# Altium schematic sheet HANC_Digital_TS.SchDoc(HANC_Digital_TS)
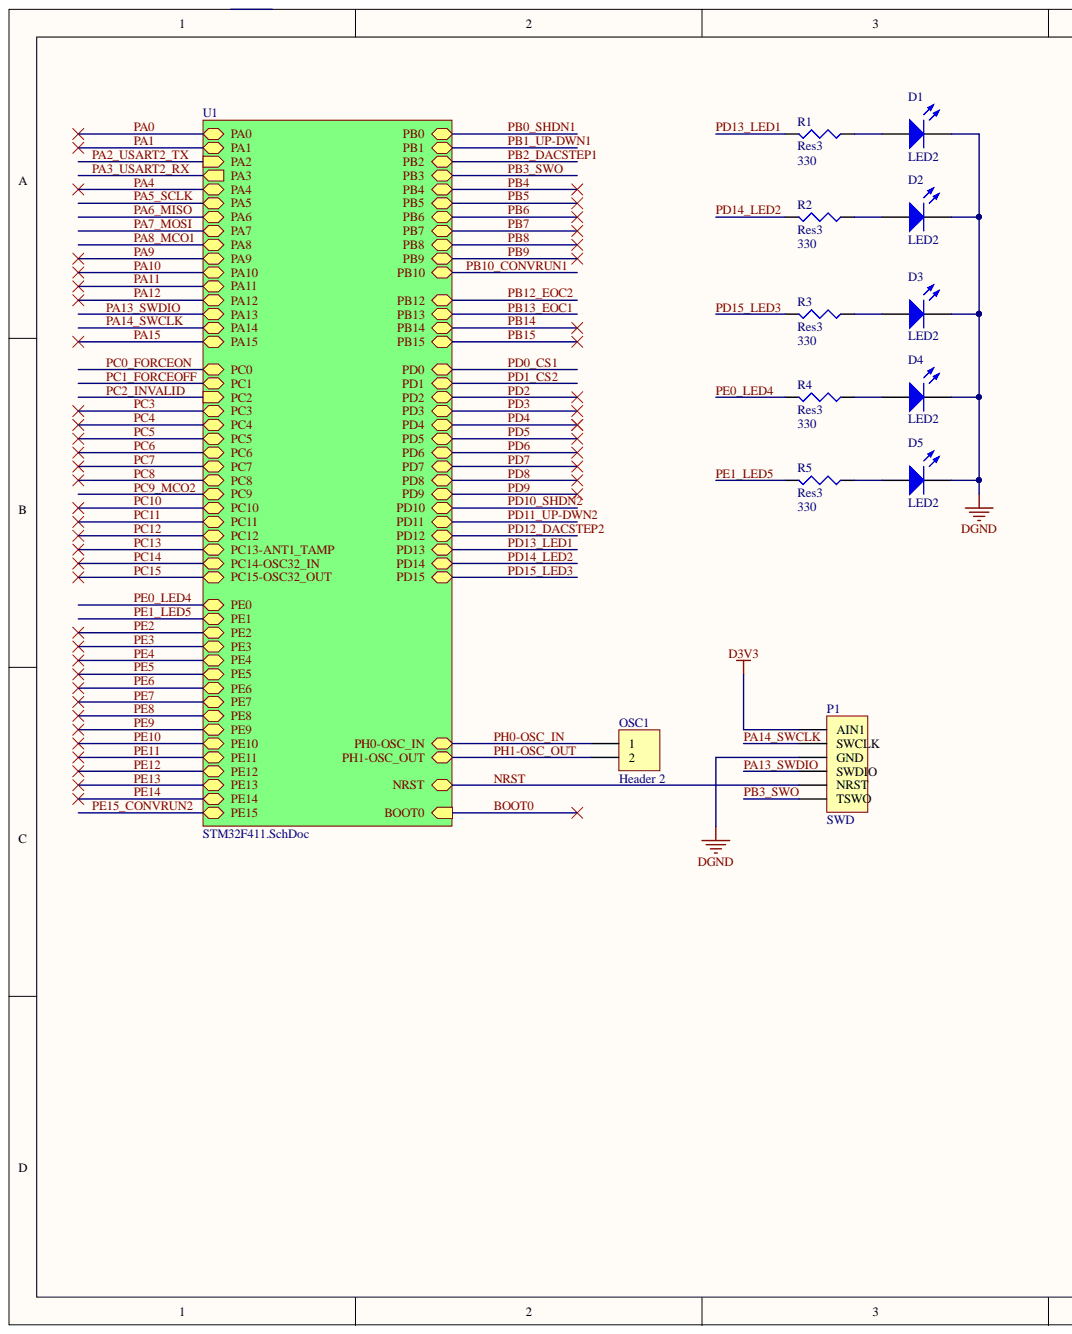
[diagram p0: HANC_Digital_TS.SchDoc, left]
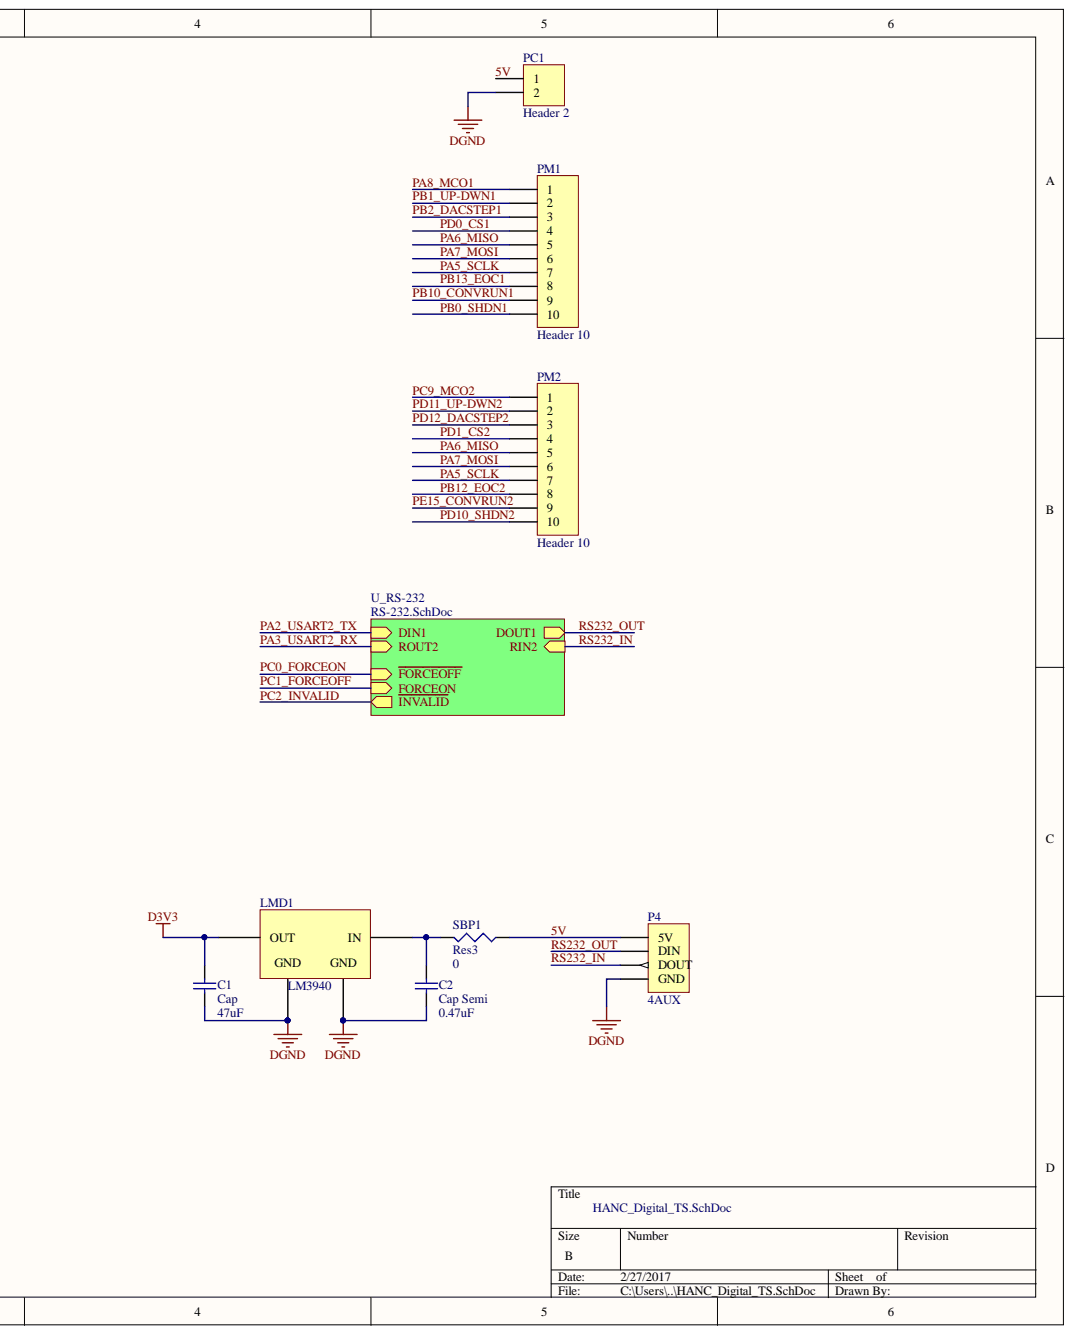
[diagram p1: HANC_Digital_TS.SchDoc, right]

## Parts
C1  Cap  value 47uF  [1608[0603]]
C2  Cap Semi  value 0.47uF  [1608[0603]]
D1  LED2  [3.2X1.6X1.1]
D2  LED2  [3.2X1.6X1.1]
D3  LED2  [3.2X1.6X1.1]
D4  LED2  [3.2X1.6X1.1]
D5  LED2  [3.2X1.6X1.1]
LMD1  LM3940  [SOT223_N]
OSC1  Header 2  [HDR1X2]
P1  SWD  [HDR1X6]
P4  4AUX  [HDR1X4]
PC1  Header 2  [HDR1X2]
PM1  Header 10  [HDR1X10]
PM2  Header 10  [HDR1X10]
R1  Res3  value 330  [0402]
R2  Res3  value 330  [0402]
R3  Res3  value 330  [0402]
R4  Res3  value 330  [0402]
R5  Res3  value 330  [0402]
SBP1  Res3  [J1-0603]

## Nets
D3V3: C1.2 LMD1.3(OUT) P1.1(AIN1)
DGND: C1.1 C2.1 D1.2 D2.2 D3.2 D4.2 D5.2 LMD1.2 LMD1.4 P1.3(GND) P4.4(GND) PC1.2
5V: P4.1(5V) PC1.1 SBP1.1
NRST: P1.5(NRST)
PA5_SCLK: PM1.7 PM2.7
PA6_MISO: PM1.5 PM2.5
PA7_MOSI: PM1.6 PM2.6
PA8_MCO1: PM1.1
PA13_SWDIO: P1.4
PA14_SWCLK: P1.2
PB0_SHDN1: PM1.10
PB1_UP-DWN1: PM1.2
PB2_DACSTEP1: PM1.3
PB3_SWO: P1.6
PB10_CONVRUN1: PM1.9
PB12_EOC2: PM2.8
PB13_EOC1: PM1.8
PC9_MCO2: PM2.1
PD0_CS1: PM1.4
PD1_CS2: PM2.4
PD10_SHDN2: PM2.10
PD11_UP-DWN2: PM2.2
PD12_DACSTEP2: PM2.3
PD13_LED1: R1.2
PD14_LED2: R2.2
PD15_LED3: R3.2
PE0_LED4: R4.2
PE1_LED5: R5.2
PE15_CONVRUN2: PM2.9
PH0-OSC_IN: OSC1.1
PH1-OSC_OUT: OSC1.2
RS232_IN: P4.3
RS232_OUT: P4.2(DIN)
NetC2_2: C2.2 LMD1.1(IN) SBP1.2
NetD1_1: D1.1 R1.1
NetD2_1: D2.1 R2.1
NetD3_1: D3.1 R3.1
NetD4_1: D4.1 R4.1
NetD5_1: D5.1 R5.1
BOOT0:
N00005:
PA0:
PA1:
PA2_USART2_TX:
PA3_USART2_RX:
PA4:
PA9:
PA10:
PA11:
PA12:
PA15:
PB4:
PB5:
PB6:
PB7:
PB8:
PB9:
PB14:
PB15:
PC0_FORCEON:
PC1_FORCEOFF:
PC2_INVALID:
PC3:
PC4:
PC5:
PC6:
PC7:
PC8:
PC10:
PC11:
PC12:
PC13:
PC14:
PC15:
PD2:
PD3:
PD4:
PD5:
PD6:
PD7:
PD8:
PD9:
PE2:
PE3:
PE4:
PE5:
PE6:
PE7:
PE8:
PE9:
PE10:
PE11:
PE12:
PE13:
PE14:

## Library data of the parts
C1 = Cap Semi: Capacitor (Semiconductor SIM Model); PartNumber: CL10A476MQENRBE; Component Kind: Standard; LatestRevisionDate: 29-May-2009; LatestRevisionNote: IPC-7351 Footprint Added.; Library Name: Miscellaneous Devices.IntLib; PackageDescription: Chip Capacitor; PackageReference: C1206; Published: 8-Jun-2000; Signal Integrity: Cap Semi; Simulation: CAP; Publisher: Altium Limited
C2 = Cap Semi: Capacitor (Semiconductor SIM Model); PartNumber: GRM033R60J474KE90D; Component Kind: Standard; LatestRevisionDate: 29-May-2009; LatestRevisionNote: IPC-7351 Footprint Added.; Library Name: Miscellaneous Devices.IntLib; PackageDescription: Chip Capacitor; PackageReference: C1206; Published: 8-Jun-2000; Signal Integrity: Cap Semi; Simulation: CAP; Publisher: Altium Limited
D1-D5 = LED2: Typical RED, GREEN, YELLOW, AMBER GaAs LED; PartNumber: LTST-C150CKT; Component Kind: Standard; LatestRevisionDate: 17-Jul-2002; LatestRevisionNote: Re-released for DXP Platform.; Library Name: Miscellaneous Devices.IntLib; PackageDescription: SM LED; 2 Flat Leads; PackageReference: 3.2X1.6X1.1; PackageVersion: Feb-2002; Published: 8-Jun-2000; Simulation: LED2; Publisher: Altium Limited
LMD1 = Component_1: PartNumber: LM3940IMP-3.3/NOPB; Component Kind: Standard; DatasheetVersion: http://www.ti.com/lit/ds/symlink/lm3940.pdf; Library Name: LN3940.SchLib
OSC1 PC1 = Header 2: Header, 2-Pin; Component Kind: Standard; LatestRevisionDate: 17-Jul-2002; LatestRevisionNote: Re-released for DXP Platform.; Library Name: Miscellaneous Connectors.IntLib; Signal Integrity: Connector; Publisher: Altium Limited
P1 = Header 6: Header, 6-Pin; Component Kind: Standard; LatestRevisionDate: 17-Jul-2002; LatestRevisionNote: Re-released for DXP Platform.; Library Name: Miscellaneous Connectors.IntLib; Signal Integrity: Connector; Publisher: Altium Limited
P4 = Header 4: Header, 4-Pin; Component Kind: Standard; LatestRevisionDate: 17-Jul-2002; LatestRevisionNote: Re-released for DXP Platform.; Library Name: Miscellaneous Connectors.IntLib; Signal Integrity: Connector; Publisher: Altium Limited
PM1 PM2 = Header 10: Header, 10-Pin; Component Kind: Standard; LatestRevisionDate: 17-Jul-2002; LatestRevisionNote: Re-released for DXP Platform.; Library Name: Miscellaneous Connectors.IntLib; Signal Integrity: Connector; Publisher: Altium Limited
R1-R5 = Res3: Resistor; PartNumber: RC0402FR-07330RL; Component Kind: Standard; LatestRevisionDate: 29-May-2009; LatestRevisionNote: IPC-7351 Footprint Added.; Library Name: Miscellaneous Devices.IntLib; PackageDescription: Chip Resistor; PackageReference: J1-0603; Published: 8-Jun-2000; Signal Integrity: Res; Simulation: RESISTOR; Publisher: Altium Limited
SBP1 = Res3: Resistor; PartNumber: Keystone Electronics 5110; Value: 0; Component Kind: Standard; LatestRevisionDate: 29-May-2009; LatestRevisionNote: IPC-7351 Footprint Added.; Library Name: Miscellaneous Devices.IntLib; PackageDescription: Chip Resistor; PackageReference: J1-0603; Published: 8-Jun-2000; Signal Integrity: Res; Simulation: RESISTOR; Publisher: Altium Limited
